# Altium schematic sheet MAIN_MicLight.SchDoc
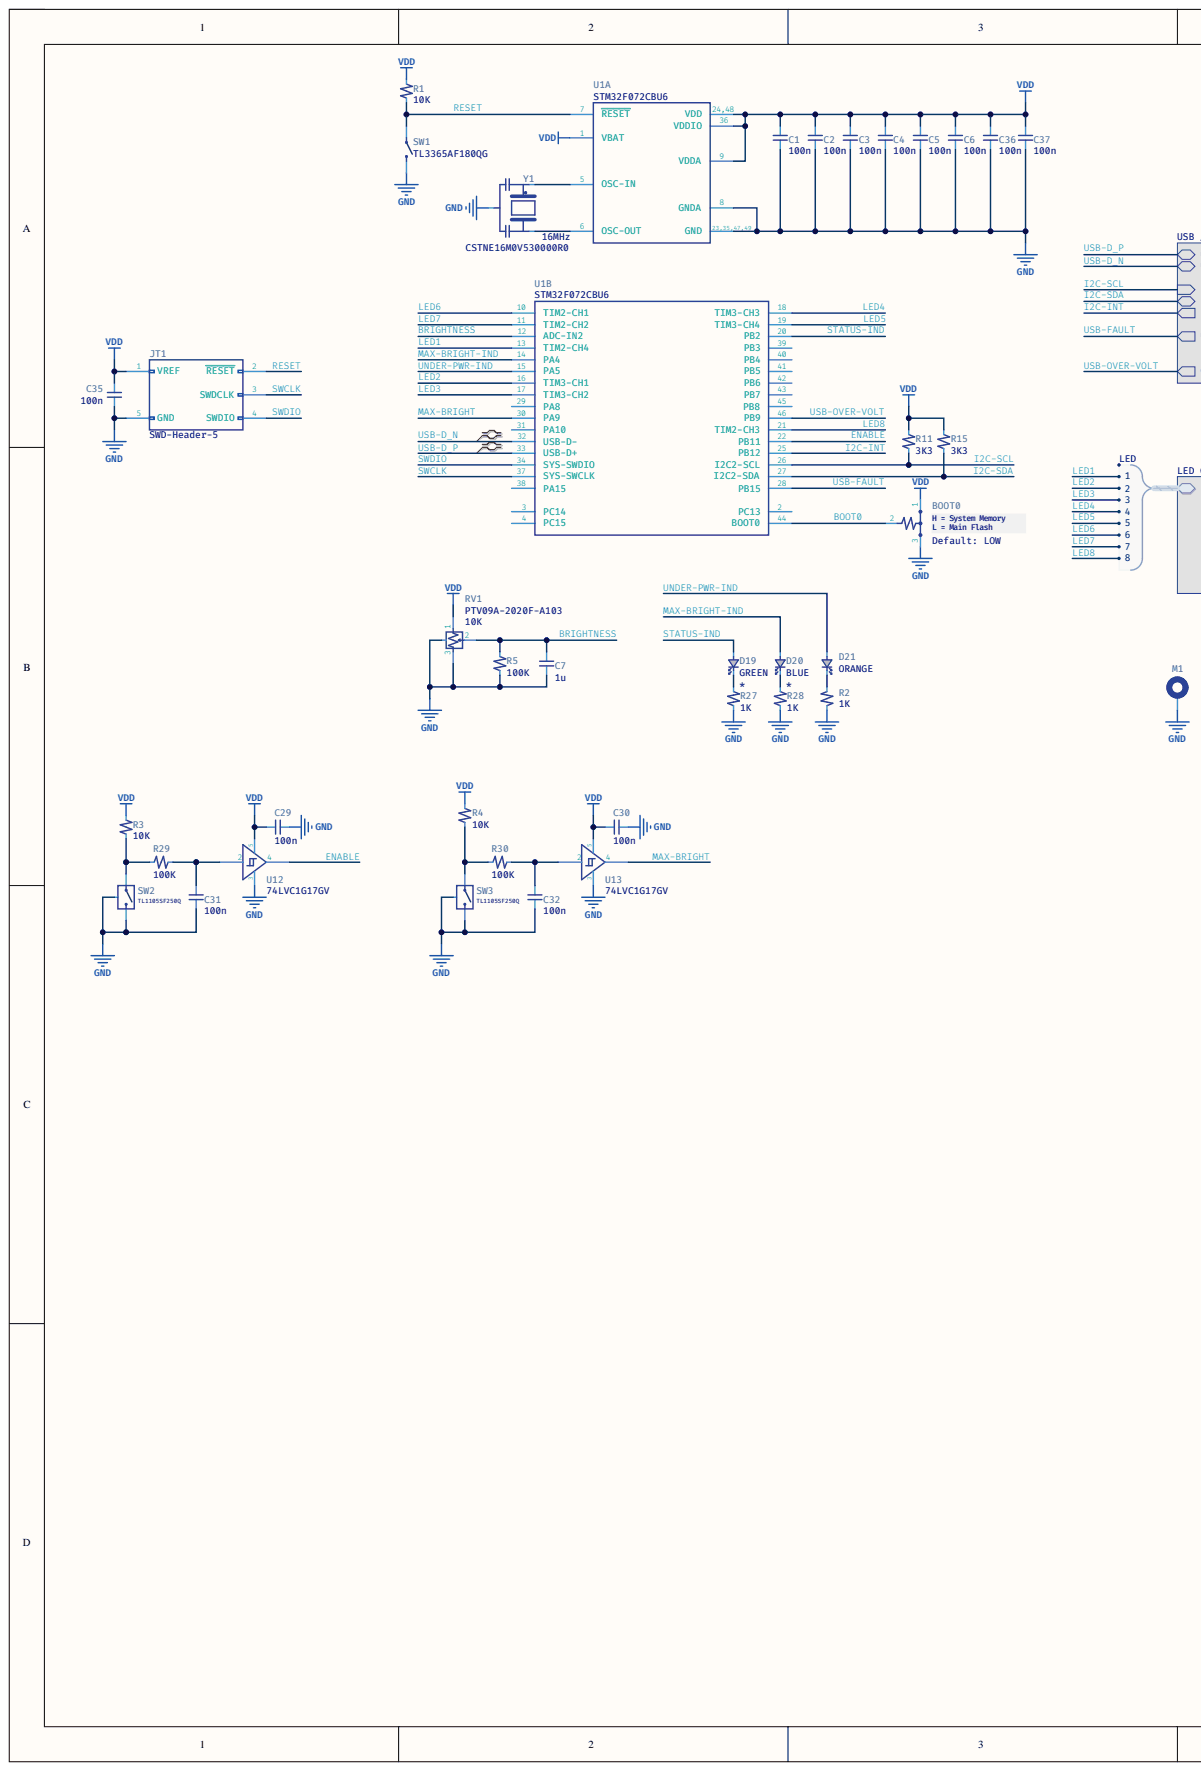
[diagram p0: MAIN_MicLight.SchDoc, left]
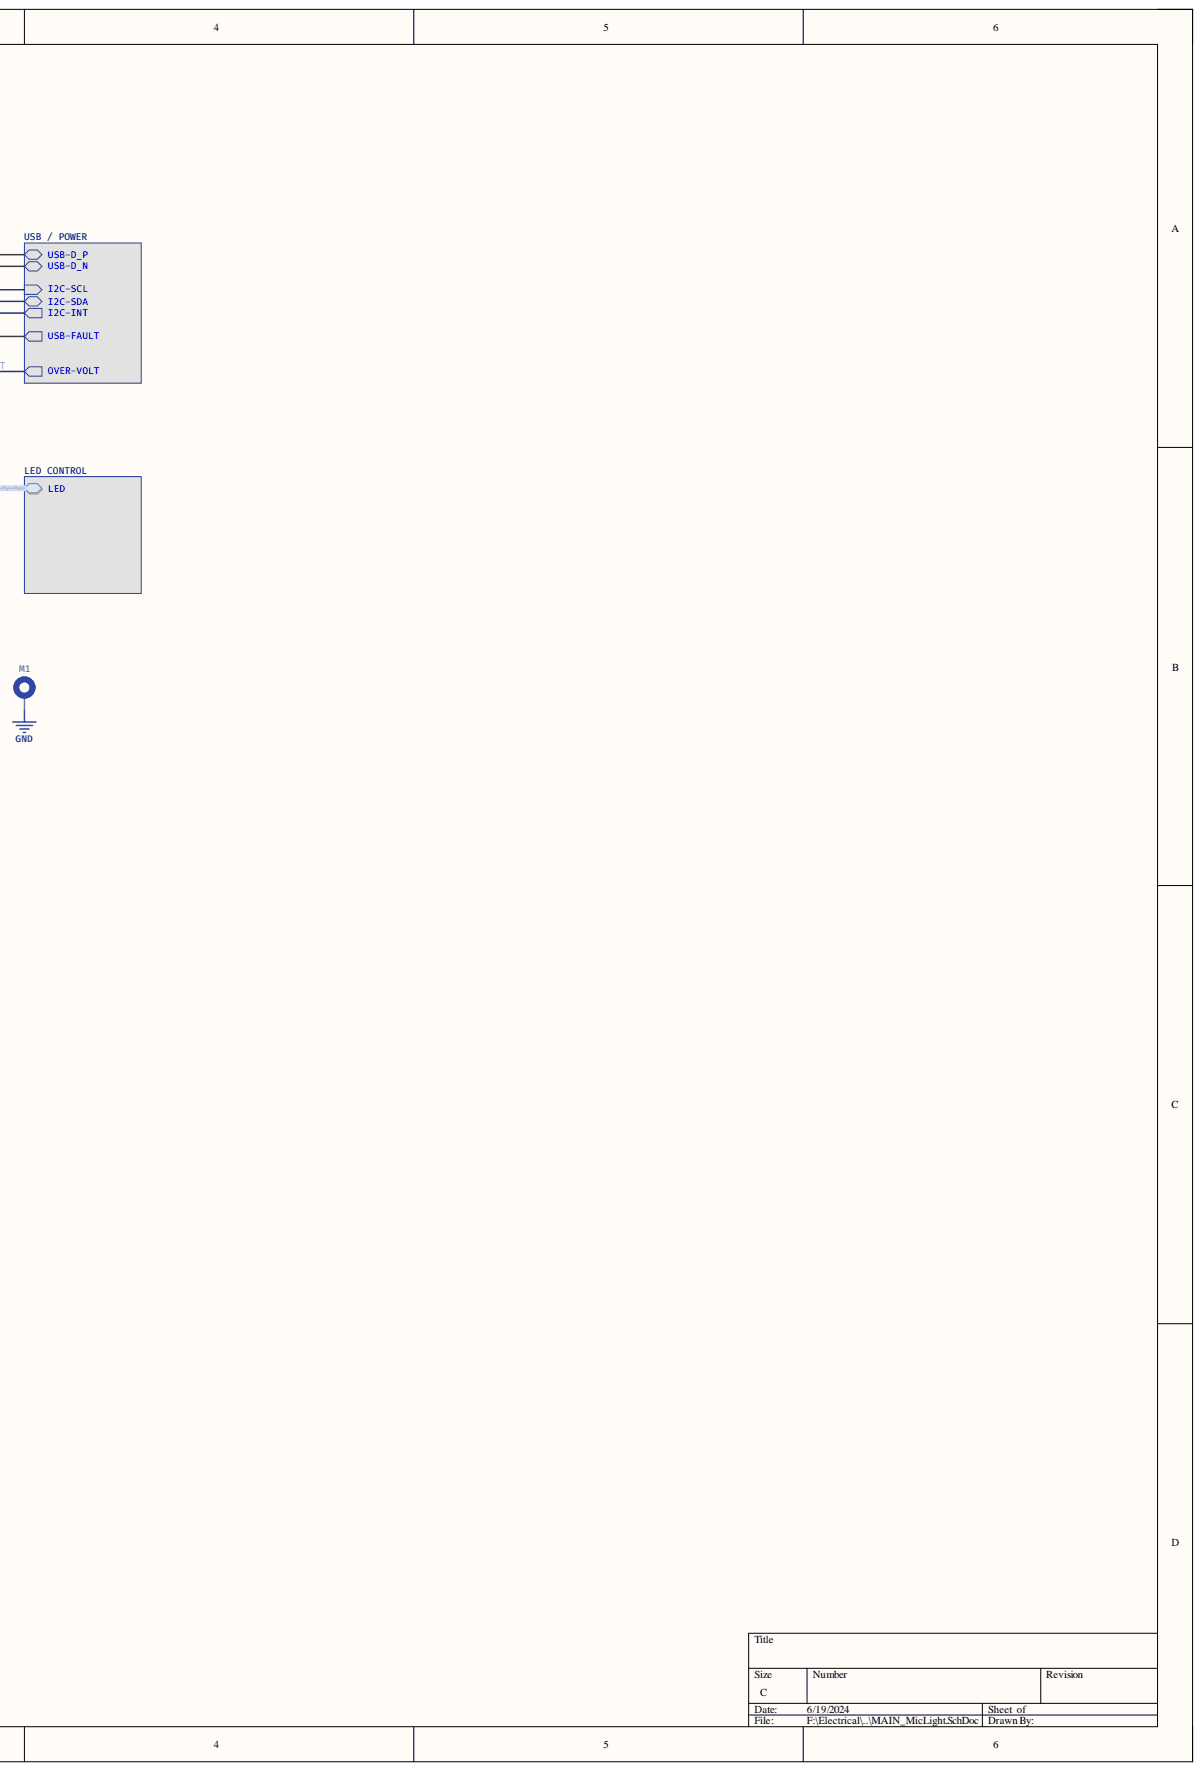
[diagram p1: MAIN_MicLight.SchDoc, right]

## Parts
BOOT0  Default: LOW  [BOOT_Header_1x03_P2.54mm_Vertical]  (hidden comment: Boot-Header-STM32)
C1  value 100n  [CAP-0402]  (hidden comment: Generic Capacitor)
C2  value 100n  [CAP-0402]  (hidden comment: Generic Capacitor)
C3  value 100n  [CAP-0402]  (hidden comment: Generic Capacitor)
C4  value 100n  [CAP-0402]  (hidden comment: Generic Capacitor)
C5  value 100n  [CAP-0402]  (hidden comment: Generic Capacitor)
C6  value 100n  [CAP-0402]  (hidden comment: Generic Capacitor)
C7  value 1u  [CAP-0402]  (hidden comment: Generic Capacitor)
C29  value 100n  [CAP-0402]  (hidden comment: Generic Capacitor)
C30  value 100n  [CAP-0402]  (hidden comment: Generic Capacitor)
C31  value 100n  [CAP-0402]  (hidden comment: Generic Capacitor)
C32  value 100n  [CAP-0402]  (hidden comment: Generic Capacitor)
C35  value 100n  [CAP-0402]  (hidden comment: Generic Capacitor)
C36  value 100n  [CAP-0402]  (hidden comment: Generic Capacitor)
C37  value 100n  [CAP-0402]  (hidden comment: Generic Capacitor)
D19  Color: GREEN  [LED-0603]  (hidden comment: General LED)
D20  Color: BLUE  [LED-0603]  (hidden comment: General LED)
D21  Color: ORANGE  [LED-0805]  (hidden comment: General LED)
JT1  SWD-Header-5  [PinHeader_1x05_P2.54mm_Vertical]
M1  Mic Hole  [Mic Hole-Footprint-1]  (value not drawn)
R1  value 10K  [RES-0402]  (hidden comment: General Resistor)
R2  value 1K  [RES-0402]  (hidden comment: General Resistor)
R3  value 10K  [RES-0402]  (hidden comment: General Resistor)
R4  value 10K  [RES-0402]  (hidden comment: General Resistor)
R5  value 100K  [RES-0402]  (hidden comment: General Resistor)
R11  value 3K3  [RES-0402]  (hidden comment: General Resistor)
R15  value 3K3  [RES-0402]  (hidden comment: General Resistor)
R27  value 1K  [RES-0402]  (hidden comment: General Resistor)
R28  value 1K  [RES-0402]  (hidden comment: General Resistor)
R29  value 100K  [RES-0402]  (hidden comment: General Resistor)
R30  value 100K  [RES-0402]  (hidden comment: General Resistor)
RV1  PTV09A-2020F-A103  Resistance: 10K  [PTV09A-2020F-A103-Footprint-1]
SW1  TL3365AF180QG  [TL3365AF180QG]
SW2  TL1105SF250Q  [TL1105SF250Q-Footprint-1]
SW3  TL1105SF250Q  [TL1105SF250Q-Footprint-1]
U1  STM32F072CBU6  [QFN-48-1EP_7x7mm_P0.5mm_EP5.6x5.6mm]  (parts A,B)
U12  74LVC1G17GV  [SOT-23-5]
U13  74LVC1G17GV  [SOT-23-5]
Y1  CSTNE16M0V530000R0  Frequency: 16MHz  [RESO-3-3x1.1mm]

## Nets
GND: BOOT0.3 C1.2 C2.2 C3.2 C4.2 C5.2 C6.2 C7.2 C29.2 C30.2 C31.2 C32.2 C35.2 C36.2 C37.2 JT1.5(GND) M1.1 R2.2 R5.1 R27.1 R28.1 RV1.3 RV1.MP SW1.2 SW2.2 SW2.MP SW3.2 SW3.MP U1.8(GNDA) U1.23,35,47,49(GND) U12.3 U13.3 Y1.2
VDD: BOOT0.1 C1.1 C2.1 C3.1 C4.1 C5.1 C6.1 C29.1 C30.1 C35.1 C36.1 C37.1 JT1.1(VREF) R1.2 R3.2 R4.2 R11.2 R15.2 RV1.1 U1.1(VBAT) U1.9(VDDA) U1.24,48(VDD) U1.36(VDDIO) U12.5 U13.5
BOOT0: BOOT0.2 U1.44(BOOT0)
BRIGHTNESS: C7.1 R5.2 RV1.2 U1.12(ADC-IN2)
ENABLE: U1.22(PB11) U12.4
I2C-INT: U1.25(PB12)
I2C-SCL: R11.1 U1.26(I2C2-SCL)
I2C-SDA: R15.1 U1.27(I2C2-SDA)
LED1: U1.13(TIM2-CH4)
LED2: U1.16(TIM3-CH1)
LED3: U1.17(TIM3-CH2)
LED4: U1.18(TIM3-CH3)
LED5: U1.19(TIM3-CH4)
LED6: U1.10(TIM2-CH1)
LED7: U1.11(TIM2-CH2)
LED8: U1.21(TIM2-CH3)
MAX-BRIGHT: U1.30(PA9) U13.4
MAX-BRIGHT-IND: D20.2 U1.14(PA4)
RESET: JT1.2(RESET) R1.1 SW1.1 U1.7(RESET)
STATUS-IND: D19.2 U1.20(PB2)
SWCLK: JT1.3(SWDCLK) U1.37(SYS-SWCLK)
SWDIO: JT1.4(SWDIO) U1.34(SYS-SWDIO)
UNDER-PWR-IND: D21.2 U1.15(PA5)
USB-D_N: U1.32(USB-D-)
USB-D_P: U1.33(USB-D+)
USB-FAULT: U1.28(PB15)
USB-OVER-VOLT: U1.46(PB9)
NetC31_1: C31.1 R29.1 U12.2
NetC32_1: C32.1 R30.1 U13.2
NetD19_1: D19.1 R27.2
NetD20_1: D20.1 R28.2
NetD21_1: D21.1 R2.1
NetR3_1: R3.1 R29.2 SW2.1
NetR4_1: R4.1 R30.2 SW3.1
NetU1_2: U1.2(PC13)
NetU1_3: U1.3(PC14)
NetU1_4: U1.4(PC15)
NetU1_5: U1.5(OSC-IN) Y1.1
NetU1_6: U1.6(OSC-OUT) Y1.3
NetU1_29: U1.29(PA8)
NetU1_31: U1.31(PA10)
NetU1_38: U1.38(PA15)
NetU1_39: U1.39(PB3)
NetU1_40: U1.40(PB4)
NetU1_41: U1.41(PB5)
NetU1_42: U1.42(PB6)
NetU1_43: U1.43(PB7)
NetU1_45: U1.45(PB8)

## Library data of the parts
BOOT0 = CMP-00035-3: Boot select pin header for STM32 ICs.; Component Kind: Standard; Library Name: Daxxn Industries
C1-C7 C29-C32 C35-C37 = C: General un-polarized capacitor; Tolerance: 5%; Component Kind: Standard; Library Name: Daxxn_Device.SchLib
D19-D21 = LED: Component Kind: Standard; ComponentLink1Description: Datasheet; ComponentLink2Description: LocalDatasheet; Description: General LED; Library Name: Daxxn_Device.SchLib
JT1 = CMP-049-000020-2: Serial Wire Debug header - without SWO.; Component Kind: Standard; Value: SWD-Header-5; Library Name: Daxxn Industries
M1 = CMP-00017-2: Sized for a 3/8 mic stand mount.; Component Kind: Standard; Library Name: Daxxn Industries
R1-R5 R11 R15 R27-R30 = R: General purpose resistor; Tolerance: 1%; Component Kind: Standard; Library Name: Daxxn_Device.SchLib
RV1 = CMP-000093-8: Res Carbon Film POT 10K Ohm 20% 1/20W 1(Elec)/1(Mech)Turn 6mm (22.6 X 19.3mm) Pin Bracket Mount/Through Hole; Actuator Diameter: 0.236inch; Actuator Length: 0.787inch; Max Power Dissipation: 50mW; Number of Gangs: 1; Component Kind: Standard; ComponentLink1Description: Datasheet; ComponentLink1URL: https://www.bourns.com/docs/Product-Datasheets/PTV09.pdf; Max Operating Temperature: 50°C; Min Operating Temperature: -10°C; Number of Turns: 1; Resistor Type: Carbon; Taper: Logarithmic; Technology: CARBON FILM; Tolerance: 20%; Type: Potentiometer; Value: PTV09A-2020F-A103; Library Name: Daxxn Industries
SW1 = CMP-000062-1: TL3365 Series SPST 12 V 4.5 x 4.5 mm 180gf Oval Top Actuated Tactile Switch; Mechanical Life: 100000Cycles; Switch Function: Off-Mom; Switch Travel: 0.2mm; Component Kind: Standard; Datasheet: https://www.farnell.com/datasheets/2628629.pdf?src-supplier=Farnell; Max Operating Temperature: 70°C; Min Operating Temperature: -20°C; Operating Force: 180gf; Throw Configuration: SPST; Value: TL3365AF180QG; Library Name: Daxxn Industries
SW2 SW3 = CMP-000094-6: Switch Tactile N.O. SPST Round Button PC Pins 0.05A 50VDC 2.45N Thru-Hole; Actuator Length: 5.8mm; Actuator Type: Standard; Contact Resistance: 100mR; Current Rating: 50mA; Operating Force: 250gf; Switch Function: Off-Mom; Switch Travel: 0.25mm; Voltage Rating (DC): 12V; Component Kind: Standard; Max Operating Temperature: 70°C; Min Operating Temperature: -20°C; Orientation: Right Angle; Series: TL1105; Throw Configuration: SPST; Value: TL1105SF250Q; Library Name: Daxxn Industries
U1 = CMP-000000-2: ARM® Cortex®-M0 STM32F0 Microcontroller IC 32-Bit Single-Core 48MHz 128KB (128K x 8) FLASH UFQFPN-48; Core Architecture: ARM; Data Bus Width: 32b; Interface: I2C,SPI,USB,CAN,HDMI,IrDA,UART,USART,LIN,I2S; Max Power Dissipation: 625mW; Max Supply Voltage: 3.6V; Min Supply Voltage: 2V; Number of I/Os: 37; Number of Timers/Counters: 9; Oscillator Type: Internal; Peripherals: POR,PWM,WDT,DMA; RAM Size: 16KB; Watchdog Timer: Yes; Clock Frequency: 48MHz; Component Kind: Standard; ComponentLink1Description: Datasheet; ComponentLink1URL: https://www.st.com/content/ccc/resource/technical/document/datasheet/cd/46/43/83/22/d3/40/c8/DM00090510.pdf/files/DM00090510.pdf/jcr:content/translations/en.DM00090510.pdf; Frequency: 48MHz; Lead Free: Lead Free; Max Operating Temperature: 85°C; Memory Format: FLASH; Memory Size: 128KB; Min Operating Temperature: -40°C; Packaging: Tray; Pins: 48; Radiation Hardening: No; Reach SVHC Compliant: No; RoHS Compliant: Yes; Series: STM32 F0; Value: STM32F072CBU6; Library Name: Daxxn Industries
U12 U13 = CMP-069-000008-2: Buffer, Non-Inverting 1 Element 1 Bit per Element Push-Pull Output SC-74A; Component Kind: Standard; ComponentLink1Description: Datasheet; ComponentLink1URL: https://assets.nexperia.com/documents/data-sheet/74LVC1G17.pdf; Design Item ID: 74LVC1G17GV; Value: 74LVC1G17GV; Library Name: Daxxn Industries; Source: Daxxn_Logic.SchLib
Y1 = CMP-000066-4: 16 MHz Ceramic Resonator Built in Capacitor 15 pF ±0.3% 40 Ohms -40°C ~ 85°C; Capacitance: 15pF; Frequency Tolerance: 0.5%; Impedance: 40R; Component Kind: Standard; ComponentLink1Description: Datasheet; ComponentLink1URL: https://www.murata.com/en/products/productdata/8801162231838/SPEC-CSTNE16M0V530000R0.pdf; Frequency Stability: 0.3%; Load Capacitance: 15pF; Max Operating Temperature: 85°C; Min Operating Temperature: -40°C; Value: CSTNE16M0V530000R0; Library Name: Daxxn Industries
